# Altium schematic sheet USB_OTG.SchDoc
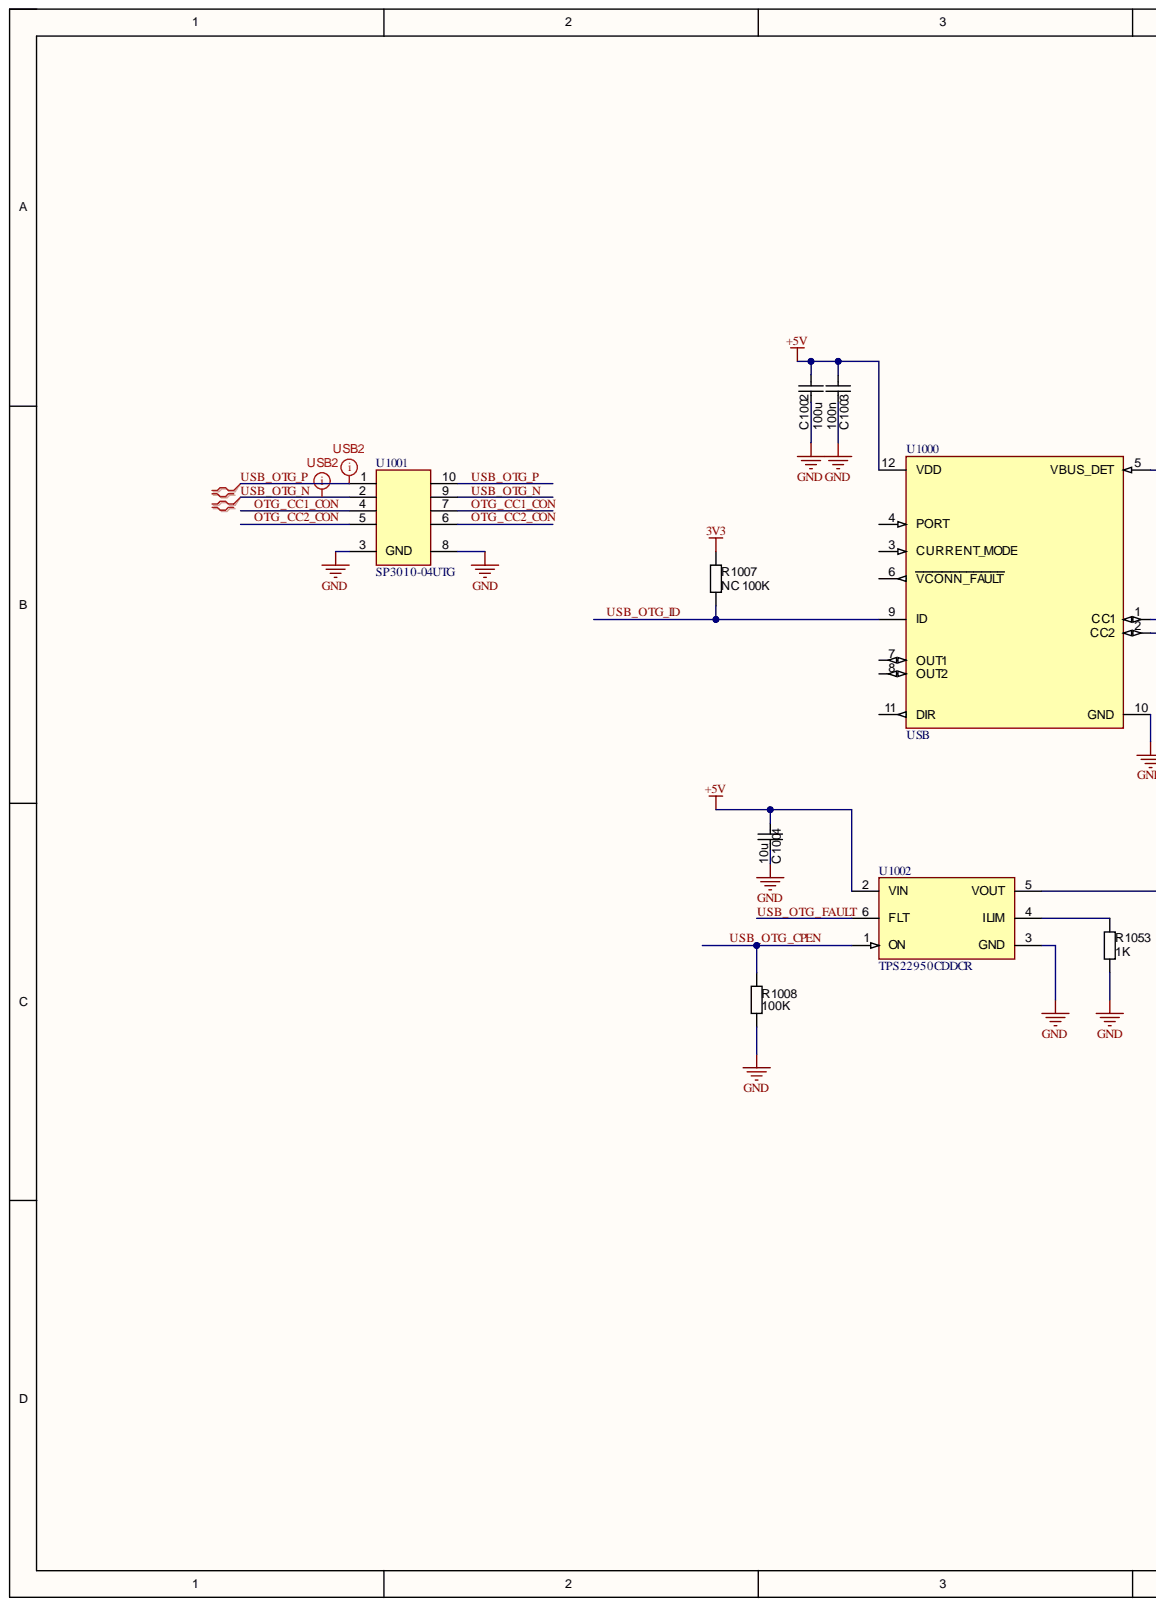
[diagram p0: USB_OTG.SchDoc, left]
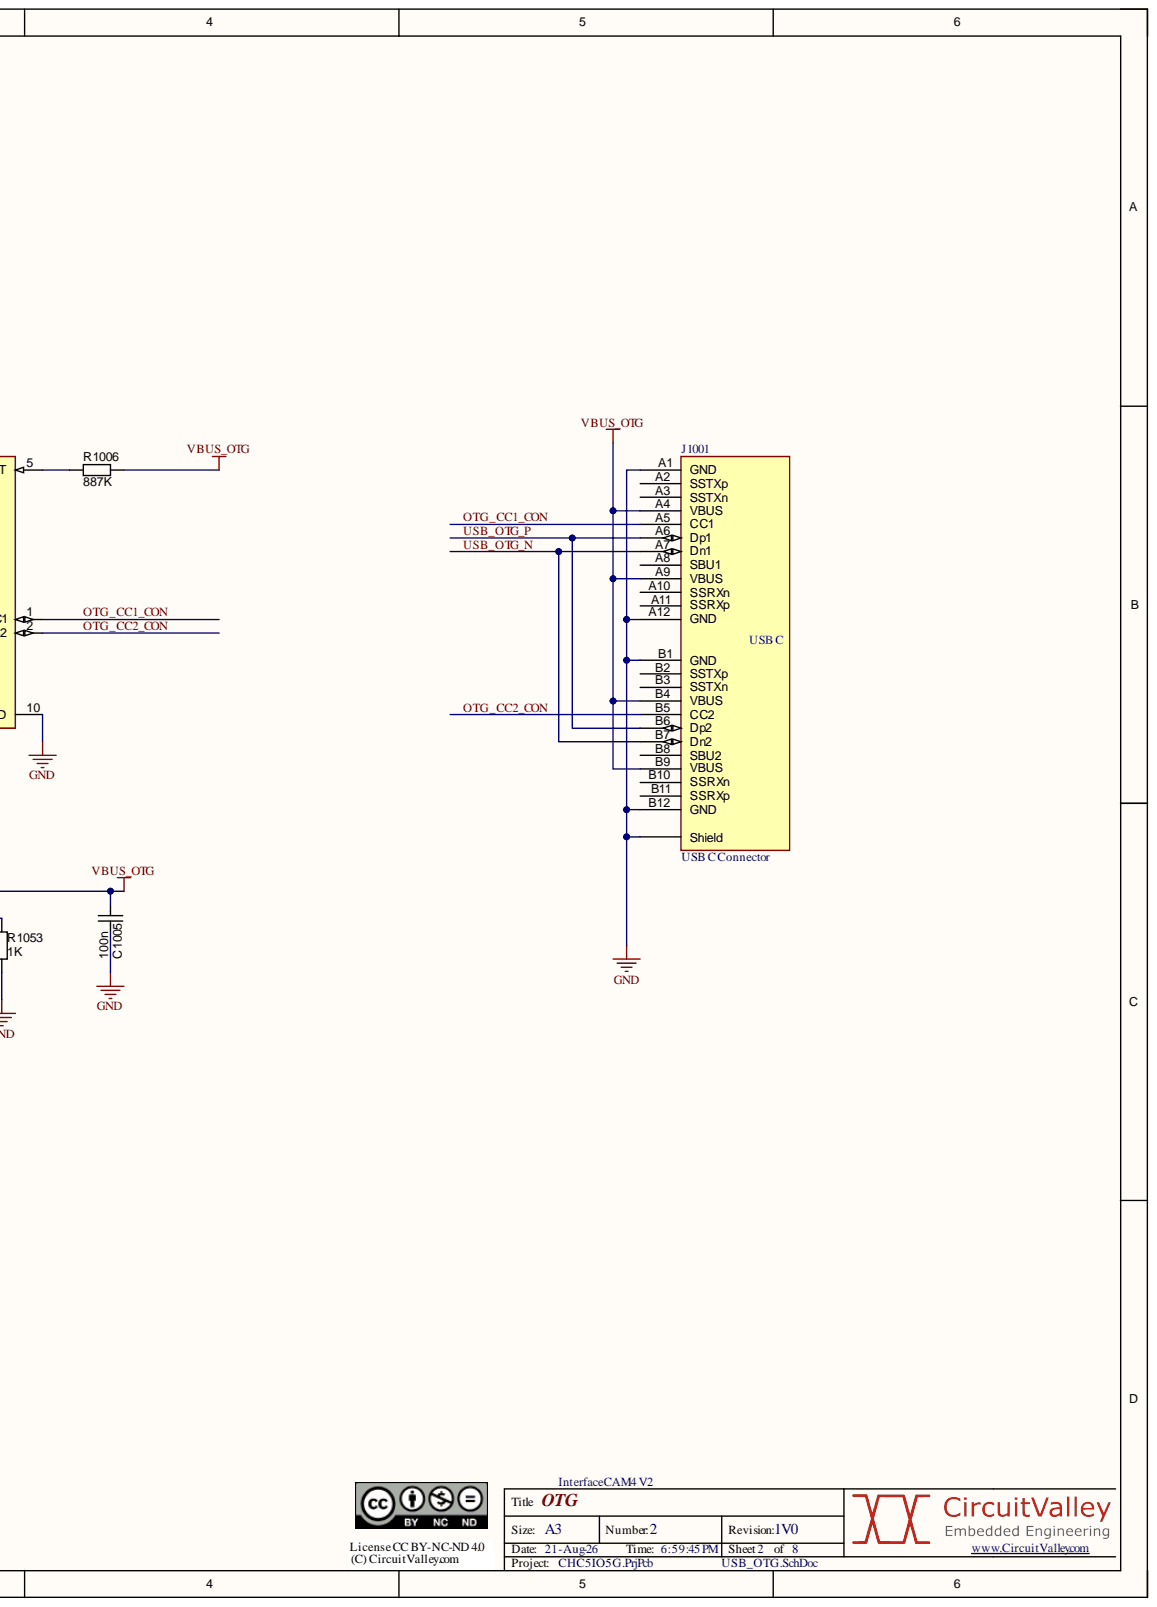
[diagram p1: USB_OTG.SchDoc, right]

## Parts
C1002  value 100u  [CAPC2012N]  (hidden comment: approved sub OK)
C1003  100n  [CAPC1005N]
C1004  value 10u  [CAPC1608N]  (hidden comment: approved sub OK)
C1005  100n  [CAPC1005N]
J1001  USB C Connector  [GCT_USB4115-03-C_REVB4]
R1006  value 887K  [RESC1005N]  (hidden comment: Thick Film)
R1007  value NC 100K  [RESC1005N]  (hidden comment: Thick Film)
R1008  value 100K  [RESC1005N]  (hidden comment: Thick Film)
R1053  value 1K  [RESC1005N]  (hidden comment: Thick Film)
U1000  USB  [X2QFN-12]
U1001  SP3010-04UTG  [uDFN-10]
U1002  TPS22950CDDCR  [SOT23-6]

## Nets
3V3: R1007.2
+5V: C1002.1 C1003.2 C1004.1 U1000.12(VDD) U1002.2(VIN)
GND: C1002.2 C1003.1 C1004.2 C1005.1 J1001.0(Shield) J1001.A1(GND) J1001.A12(GND) J1001.B1(GND) J1001.B12(GND) R1008.1 R1053.1 U1000.10(GND) U1001.3(GND) U1001.8 U1002.3(GND)
VBUS_OTG: C1005.2 J1001.A4(VBUS) J1001.A9(VBUS) J1001.B4(VBUS) J1001.B9(VBUS) R1006.2 U1002.5(VOUT)
OTG_CC1_CON: J1001.A5(CC1) U1000.1(CC1) U1001.4 U1001.7
OTG_CC2_CON: J1001.B5(CC2) U1000.2(CC2) U1001.5 U1001.6
USB_OTG_CPEN: R1008.2 U1002.1(ON)
USB_OTG_FAULT: U1002.6(FLT)
USB_OTG_ID: R1007.1 U1000.9(ID)
USB_OTG_N: J1001.A7(Dn1) J1001.B7(Dn2) U1001.2 U1001.9
USB_OTG_P: J1001.A6(Dp1) J1001.B6(Dp2) U1001.1 U1001.10
NetR1006_1: R1006.1 U1000.5(VBUS_DET)
NetR1053_2: R1053.2 U1002.4(ILIM)

Not connected: J1001 A2(SSTXp) A3(SSTXn) A8(SBU1) A10(SSRXn) A11(SSRXp) B2(SSTXp) B3(SSTXn) B8(SBU2) B10(SSRXn) B11(SSRXp); U1000 3(CURRENT_MODE) 4(PORT) 6(VCONN_FAULT) 7(OUT1) 8(OUT2) 11(DIR)

## Library data of the parts
C1002 = CAPC_1u_0805: Capacitor SMD Cer 1uF 10% 16V X7R 0805; Manufacturer 1: TDK Corporation; Manufacturer Part Number 1: C2012X7R1C105K/1.25; Material: ceramic; Ratings: 16V; X7R; Component Kind: Standard; Library Name: PBL - Capacitors.SCHLIB; Package / Case: 0805 (2012 metric); Supplier 1: Digi-Key; Supplier Part Number 1: 445-1358-1-ND; Tolerance: ±10%
C1003 C1005 = CAPC_10n_0402_16V_10%: Capacitor SMD Cer 10nF 10% 16V X7R 0402; Manufacturer 1: AVX Corporation; Manufacturer Part Number 1: 0402YC103KAT2A; Ratings: 16V; X7R; Component Kind: Standard; ComponentLink1Description: http://www.avx.com/docs/Catalogs/cx7r.pdf; ComponentLink1URL: http://www.avx.com/docs/Catalogs/cx7r.pdf; ComponentLink2Description: http://www.yageo.com/documents/recent/UPY-GPHC_X7R_6.3V-to-50V_8.pdf; ComponentLink2URL: http://www.yageo.com/documents/recent/UPY-GPHC_X7R_6.3V-to-50V_8.pdf; Library Name: PBL - Capacitors.SCHLIB; Manufacturer 2: Yageo; Manufacturer Part Number 2: CC0402KRX7R7BB103; Operating Temperature: -55°C ~ 125°C; Package / Case: 0402 (1005 Metric); Size / Dimension: 0.039" L x 0.020" W (1.00mm x 0.50mm); Supplier 1: Digi-Key; Supplier 2: Digi-Key; Supplier Part Number 1: 478-1114-1-ND; Supplier Part Number 2: 311-1042-1-ND; Tolerance: ±10%; Value: 100n
C1004 = CAPC_560p_0603_5%: Capacitor SMD Cer 560pF 5% 50V NP0 0603; Manufacturer 1: AVX Corporation; Manufacturer Part Number 1: 06035A561JAT2A; Capacitance: 470pF; Material: ceramic; Ratings: 50V; NP0; Applications: General Purpose; Component Kind: Standard; ComponentLink1Description: http://www.avx.com/docs/Catalogs/ccog.pdf; ComponentLink1URL: http://www.avx.com/docs/Catalogs/ccog.pdf; Height - Seated (Max): -; Lead Spacing: -; Lead Style: -; Library Name: PBL - Capacitors.SCHLIB; Mounting Type: Surface Mount, MLCC; Operating Temperature: -55°C ~ 125°C; Package / Case: 0603 (1608 metric); Size / Dimension: 0.063" L x 0.032" W (1.60mm x 0.81mm); Supplier 1: Digi-Key; Supplier Part Number 1: 478-1184-1-ND; Thickness (Max): 0.035" (0.90mm); Voltage - Rated: 50V
J1001 = USB_C: Value: USB4115-03-C; Component Kind: Standard; Description: USB C connector; Library Name: cvlib.SchLib
R1006-R1008 R1053 = RES_3K3_0402_5%: Resistor SMD chip 3.3k Ohm 0.063W 5% 0402; Manufacturer 1: Yageo; Manufacturer Part Number 1: RC0402JR-073K3L; Ratings: 1/16 W; Component Kind: Standard; ComponentLink1Description: http://www.yageo.com/documents/recent/PYu-RC0402_51_RoHS_L_6.pdf; ComponentLink1URL: http://www.yageo.com/documents/recent/PYu-RC0402_51_RoHS_L_6.pdf; Library Name: PBL - Resistors.SCHLIB; Material: Thick Film; Package / Case: 0402 (1005 metric); Supplier 1: Digi-Key; Supplier Part Number 1: 311-3.3KJRCT-ND; Tolerance: ±5%
U1000 = TUSB321AI: USB Type-C Configuration Channel Logic; Component Kind: Standard; Library Name: cvlib.SchLib
U1001 = SP3010-04UTG: ESD Protection; Component Kind: Standard; Library Name: cvlib.SchLib
U1002 = TPS22950CDDCR: Power Switch; Component Kind: Standard; Library Name: cvlib.SchLib
